# Altium schematic sheet Harnesses.SchDoc
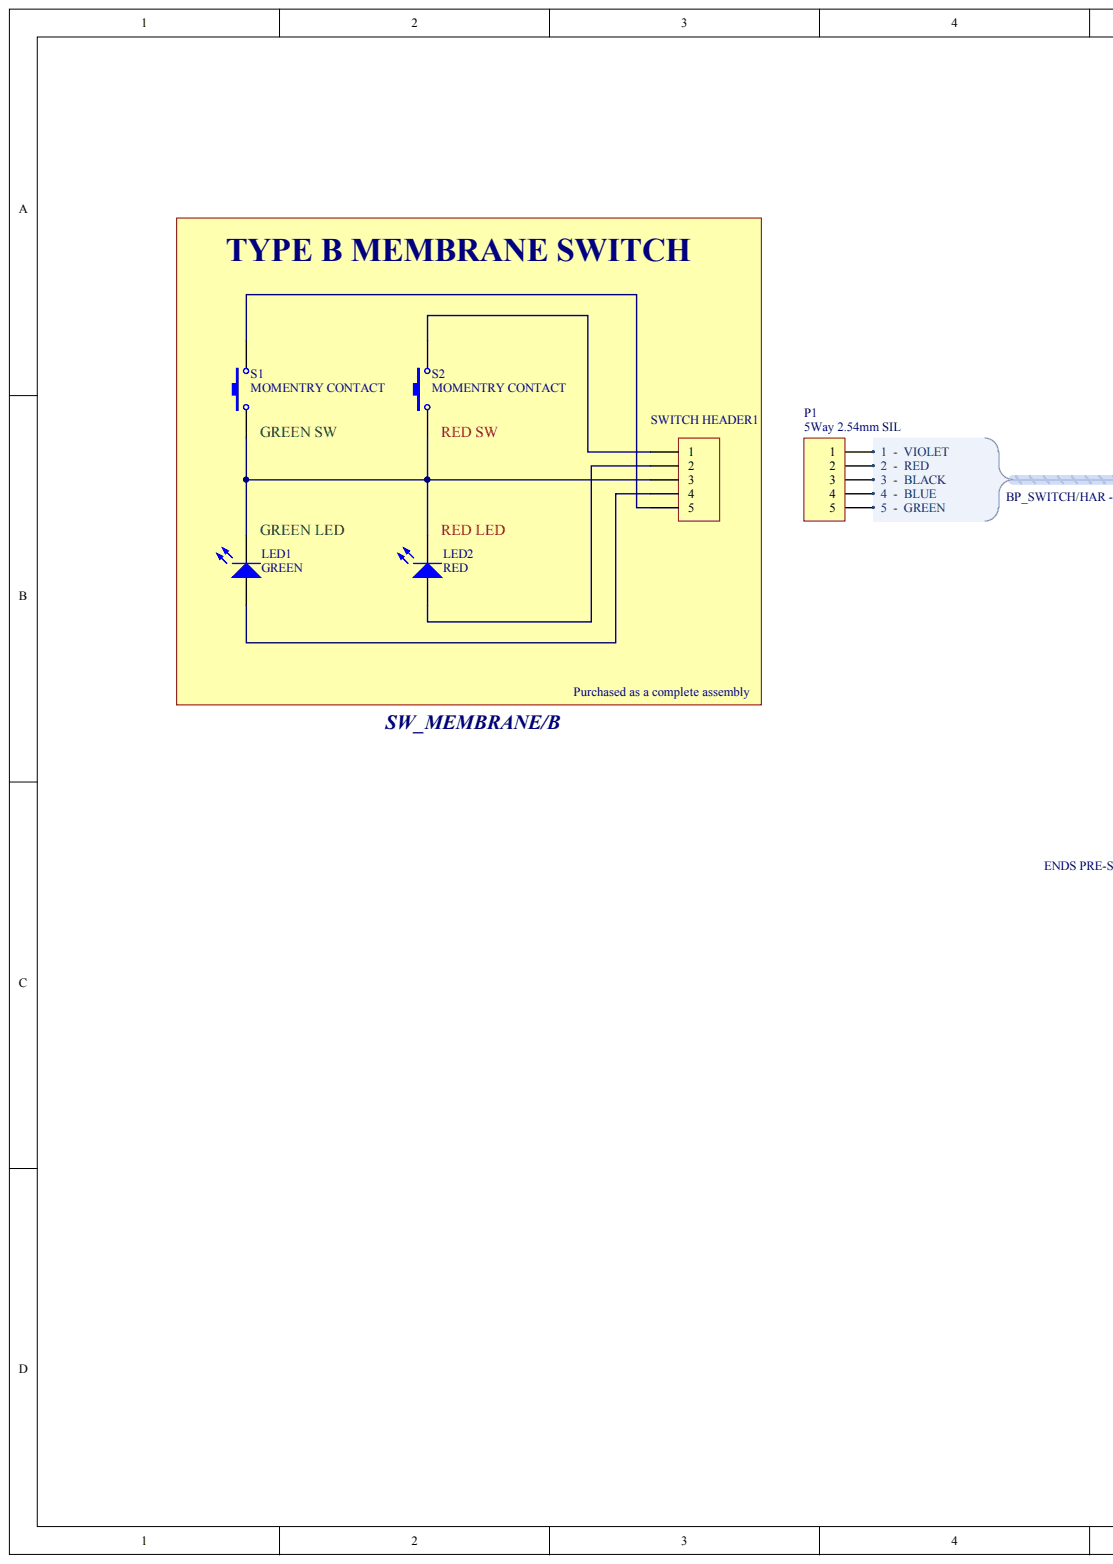
[diagram p0: Harnesses.SchDoc, left]
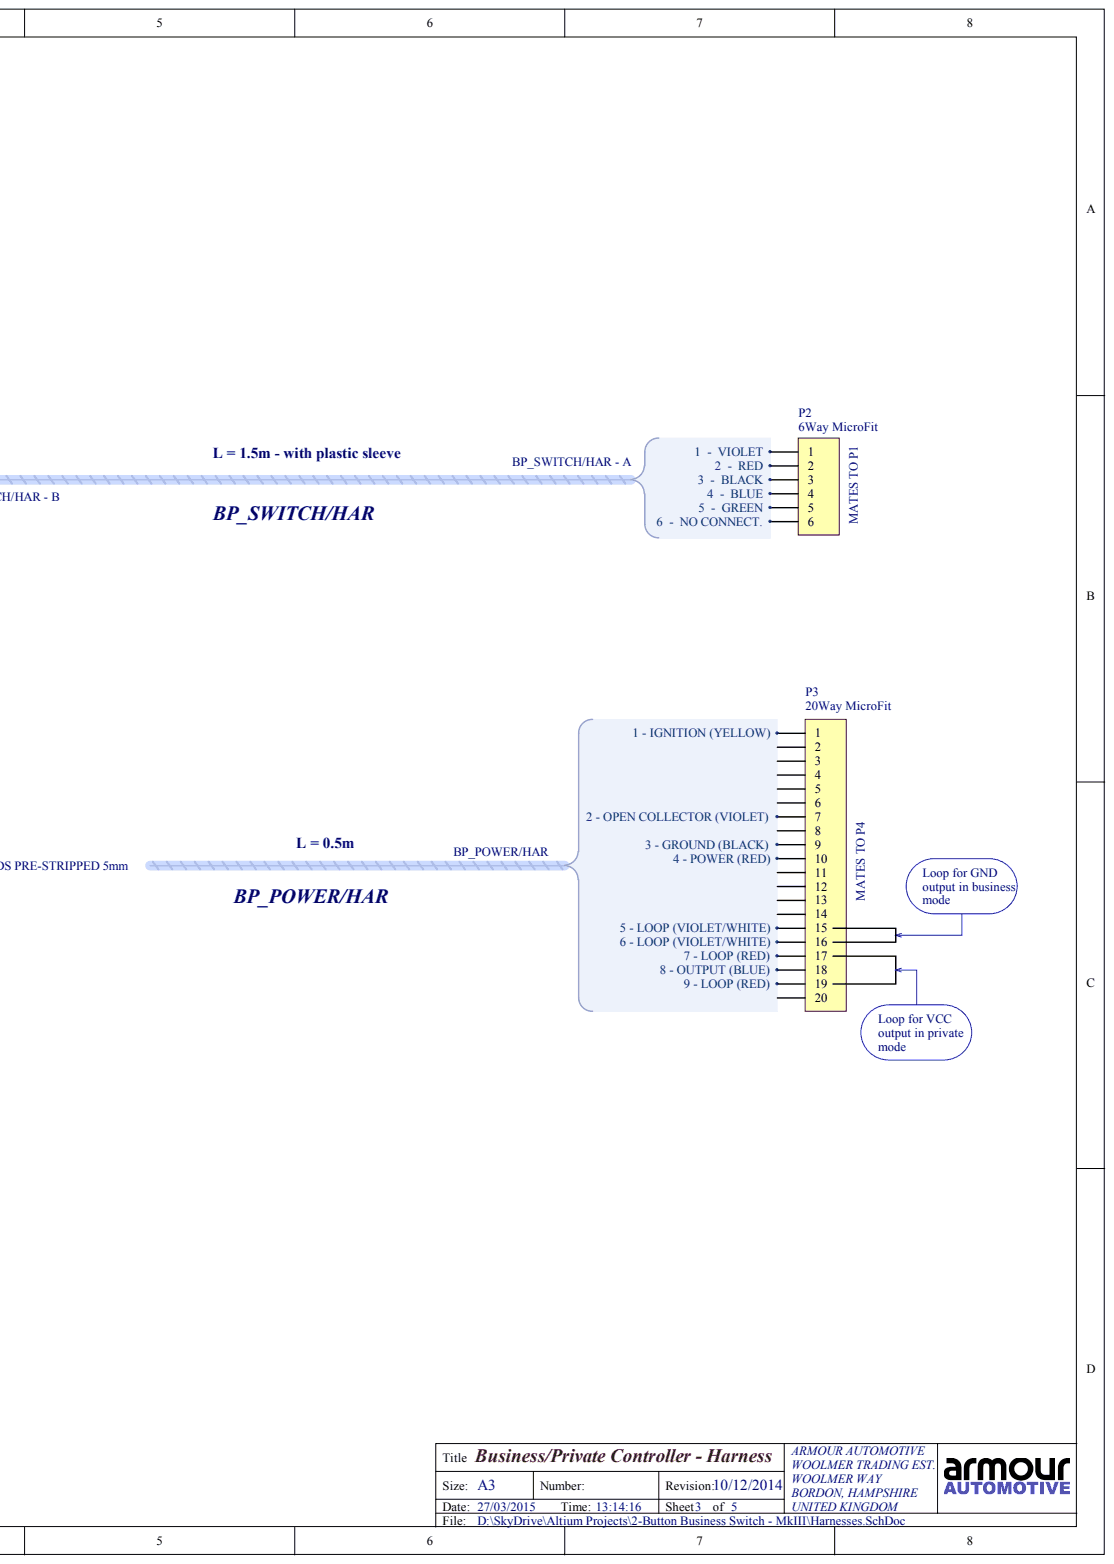
[diagram p1: Harnesses.SchDoc, right]

## Parts
LED1  GREEN  [3.2X1.6X1.1]
LED2  RED  [3.2X1.6X1.1]
P1  5Way 2.54mm SIL  [MHDR1X5]
P2  6Way MicroFit  [HDR1X6]
P3  20Way MicroFit  [HDR2X10]
S1  MOMENTRY CONTACT  [SPST-2]
S2  MOMENTRY CONTACT  [SPST-2]
SWITCH_HEADER1  (no value)  [HDR1X5]

## Nets
NetLED1_1: LED1.1 SWITCH_HEADER1.4
NetLED1_2: LED1.2 LED2.2 S1.1 S2.1 SWITCH_HEADER1.3
NetLED2_1: LED2.1 SWITCH_HEADER1.2
NetS1_2: S1.2 SWITCH_HEADER1.5
NetS2_2: S2.2 SWITCH_HEADER1.1

Not connected: P1 1 2 3 4 5; P2 1 2 3 4 5 6; P3 1 2 3 4 5 6 7 8 9 10 11 12 13 14 15 16 17 18 19 20

## Library data of the parts
LED1 = LED1: Typical GREEN GaAs LED; Component Kind: Standard; LatestRevisionDate: 08-Jul-2005; LatestRevisionNote: Mech Layer 1 removed.; Library Name: Miscellaneous Devices.IntLib; PackageDescription: LED; 2 Leads; PackageReference: LED-1; Published: 8-Jun-2000; Simulation: LED1; Publisher: Altium Limited
LED2 = LED1: Typical RED GaAs LED; Component Kind: Standard; LatestRevisionDate: 08-Jul-2005; LatestRevisionNote: Mech Layer 1 removed.; Library Name: Miscellaneous Devices.IntLib; PackageDescription: LED; 2 Leads; PackageReference: LED-1; Published: 8-Jun-2000; Simulation: LED1; Publisher: Altium Limited
P1 = MHDR1X5: Header, 5-Pin; Component Kind: Standard; LatestRevisionDate: 17-Jul-2002; LatestRevisionNote: Re-released for DXP Platform.; Library Name: Miscellaneous Connectors.IntLib; Signal Integrity: Connector; Publisher: Altium Limited
P2 = Header 6: Component Kind: Standard; LatestRevisionDate: 17-Jul-2002; LatestRevisionNote: Re-released for DXP Platform.; Library Name: Miscellaneous Connectors.IntLib; Signal Integrity: Connector; Publisher: Altium Limited
P3 = MHDR1X20: Component Kind: Standard; LatestRevisionDate: 17-Jul-2002; LatestRevisionNote: Re-released for DXP Platform.; Library Name: Miscellaneous Connectors.IntLib; Signal Integrity: Connector; Publisher: Altium Limited
S1 S2 = SW-PB: Switch; Component Kind: Standard; LatestRevisionDate: 17-Jul-2002; LatestRevisionNote: Re-released for DXP Platform.; Library Name: Miscellaneous Devices.IntLib; PackageDescription: Switch; 2 Leads; PackageReference: SPST-2; Published: 24-Mar-1999; Publisher: Altium Limited
SWITCH_HEADER1 = Header 5: Header, 5-Pin; Component Kind: Standard; LatestRevisionDate: 17-Jul-2002; LatestRevisionNote: Re-released for DXP Platform.; Library Name: Miscellaneous Connectors.IntLib; Signal Integrity: Connector; Publisher: Altium Limited
